# Altium schematic sheet Schemat.SchDoc
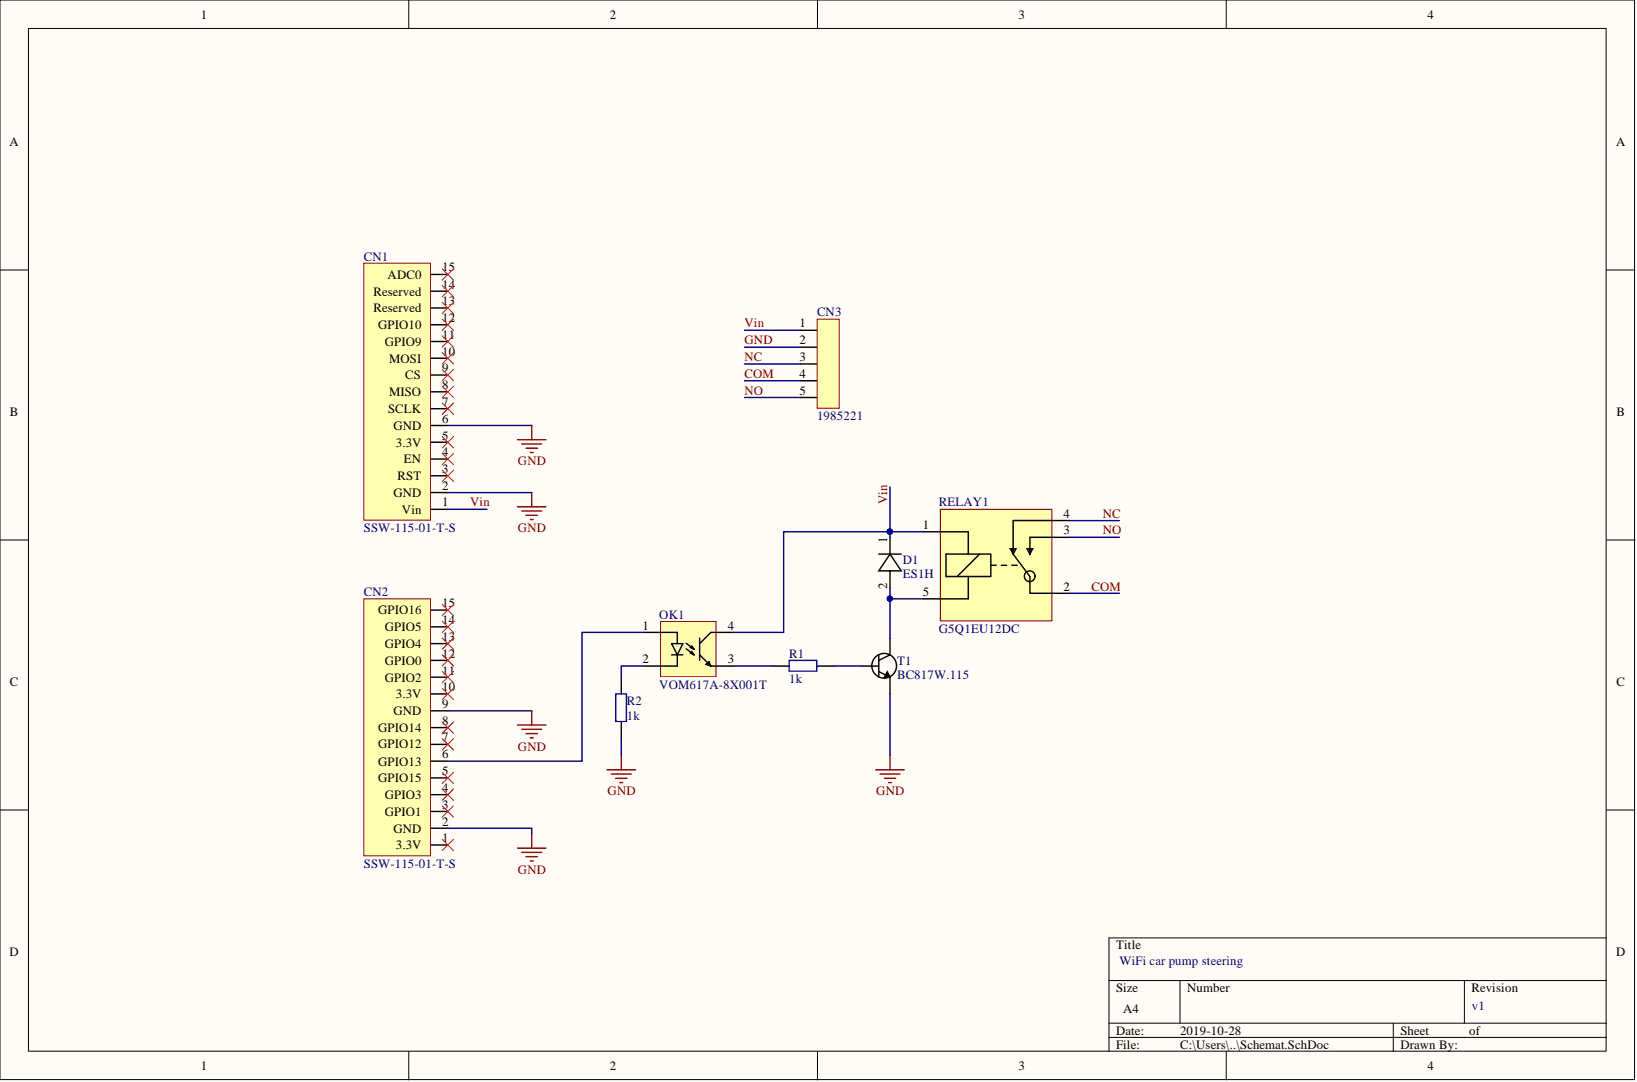
[diagram p0: Schemat.SchDoc]

## Parts
CN1  SSW-115-01-T-S  [SSW-115-01-T-S]
CN2  SSW-115-01-T-S  [SSW-115-01-T-S]
CN3  1985221  [PTSA 1.5/5-3,5-Z]
D1  ES1H  [DIOM4326X25N]
OK1  VOM617A-8X001T  [SOP254P700X200-4M]
R1  Resistance: 1k  [Resistor 1206]  (hidden comment: 1206 resistor)
R2  Resistance: 1k  [Resistor 1206]  (hidden comment: 1206 resistor)
RELAY1  G5Q1EU12DC  [G5Q-1EU 12DC]
T1  BC817W.115  [BC817W.115]

## Nets
COM: CN3.4 RELAY1.2
GND: CN1.2(GND) CN1.6(GND) CN2.2(GND) CN2.9(GND) CN3.2 R2.2 T1.2
NC: CN3.3 RELAY1.4
NO: CN3.5 RELAY1.3
Vin: CN1.1(Vin) CN3.1 D1.1 OK1.4 RELAY1.1
NetCN2_6: CN2.6(GPIO13) OK1.1
NetD1_2: D1.2 RELAY1.5 T1.3
NetOK1_2: OK1.2 R2.1
NetOK1_3: OK1.3 R1.1
NetR1_2: R1.2 T1.1

Not connected: CN1 3(RST) 4(EN) 5(3.3V) 7(SCLK) 8(MISO) 9(CS) 10(MOSI) 11(GPIO9) 12(GPIO10) 13(Reserved) 14(Reserved) 15(ADC0); CN2 1(3.3V) 3(GPIO1) 4(GPIO3) 5(GPIO15) 7(GPIO12) 8(GPIO14) 10(3.3V) 11(GPIO2) 12(GPIO0) 13(GPIO4) 14(GPIO5) 15(GPIO16)

## Library data of the parts
CN1 CN2 = SSW-115-01-T-S: SAMTEC         SSW-115-01-T-S             Board-To-Board Connector, SSW Series, 15 Contacts, Receptacle, 2.54 mm, Through Hole, 1 Rows; Connector Type: Receptacle,Socket; Contact Resistance: 10mR; Mating Cycles: 100; Max Current Rating: 6.9A; Max Voltage Rating (AC): 550V; Number of Contacts: 15; Pitch: 2.54mm; Color: Black; Component Kind: Standard; ComponentLink1Description: http://suddendocs.samtec.com/prints/ssw-1xx-xx-xxx-x-xx-xxx-xx-mkt.pdf?src-supplier=Digi-Key; ComponentLink1URL: http://suddendocs.samtec.com/prints/ssw-1xx-xx-xxx-x-xx-xxx-xx-mkt.pdf?src-supplier=Digi-Key; Contact Material: Bronze; Contact Plating: Tin,Matte; Depth: 2.41mm; Gender: Receptacle; Housing Color: Black; Housing Material: Polymer; Lead Free: Lead Free; Length: 38.61mm; Manufacturer: Samtec; Manufacturer Part Number: SSW-115-01-T-S; Max Operating Temperature: 105°C; Min Operating Temperature: -55°C; Mount: Through Hole; Number of Positions: 15; Number of Rows: 1; Orientation: Straight; Packaging: Bulk; Radiation Hardening: No; REACH SVHC: No SVHC; Stock 1: 0; Supplier 1: Farnell; Supplier Part Number 1: 1804177; Termination: Solder; Width: 2.41mm; Library Name: librariesForWifiPumpSteeringProject.SchLib
CN3 = 1985221: TERM BLOCK 5POS 45DEG 3.5MM PCB; Manufacturer: Phoenix Contact; Approvals: UL,VDE; Current: 2A; Max Supply Voltage: 250V; Number of Circuits: 5; Number of Levels: 1; Stripping Length: 8mm; Voltage: 300V; Wire/Cable Gauge: 16AWG; Wire Gauge (Max): 24AWG; Color: Green; Component Kind: Standard; ComponentLink1Description: http://components.arrow.com/part/dataview/43946157S8410404N1555?src-supplier=Arrow; ComponentLink1URL: http://components.arrow.com/part/dataview/43946157S8410404N1555?src-supplier=Arrow; ComponentLink2Description: https://media.digikey.com/pdf/Data%20Sheets/Phoenix%20Contact%20PDFs/COMBICON%20Spring-Cage%20PCB%20Term.%20Blocks.pdf?src-supplier=Digi-Key; ComponentLink2URL: https://media.digikey.com/pdf/Data%20Sheets/Phoenix%20Contact%20PDFs/COMBICON%20Spring-Cage%20PCB%20Term.%20Blocks.pdf?src-supplier=Digi-Key; Contact Material: Tin; Current Rating: 2A; Depth: 12mm; Flammability Rating: UL94 V-2; Height: 13.1mm; Housing Color: Green; Housing Material: Polyamide; Insulation Material: Nylon; Lead Free: Lead Free; Length: 12mm; Manufacturer Part Number: 1985221; Material: Nylon; Max Current Rating: 2A; Mount: Through Hole; Number of Contacts: 5; Number of Pins: 5; Number of Poles: 5; Number of Positions: 5; Number of Positions Per Level: 5; Number of Rows: 1; Package Quantity: 100; Packaging: Bulk; Pitch: 3.5mm; REACH SVHC: Unknown; Stock 1: 178; Supplier 1: Farnell; Supplier Part Number 1: 1792772; Termination: Solder; Voltage Rating: 250V; Weight: 0.087479oz; Width: 19mm; Wire Gauge (Min): 16AWG; Library Name: librariesForWifiPumpSteeringProject.SchLib
D1 = ES1H: Rectifiers 1A,500V,SUPER FAST Surf Mt Rect; Manufacturer: Taiwan Semiconductor; Element Configuration: Single; Forward Voltage: 1.7V; Peak Reverse Current: 100uA; Reverse Recovery Time: 35ns; Component Kind: Standard; ComponentLink1Description: http://uk.rs-online.com/webdocs/1298/0900766b81298786.pdf?src-supplier=RSComponents; ComponentLink1URL: http://uk.rs-online.com/webdocs/1298/0900766b81298786.pdf?src-supplier=RSComponents; ComponentLink2Description: http://www.taiwansemi.com/DSfile/ES1A%20SERIES_H13.pdf?src-supplier=Mouser; ComponentLink2URL: http://www.taiwansemi.com/DSfile/ES1A%20SERIES_H13.pdf?src-supplier=Mouser; Forward Current: 1A; Height: 2.5mm; Lead Free: Lead Free; Length: 4.6mm; Manufacturer Part Number: ES1H; Max Operating Temperature: 150°C; Max Repetitive Reverse Voltage (Vrrm): 500V; Min Operating Temperature: -55°C; Mount: Surface Mount; Number of Pins: 2; Package Quantity: 7500; Packaging: Tape and Reel; REACH SVHC: No SVHC; Stock 1: 0; Supplier 1: Farnell; Supplier Part Number 1: 2677280; Width: 2.83mm; Library Name: librariesForWifiPumpSteeringProject.SchLib
OK1 = VOM617A-8X001T: VISHAY         VOM617A-8X001T             Transistor Output Optocoupler, Low Input Current, 1 Channel, SOP, 4 Pins, 60 mA, 3.75 kV, 130 %; Collector Emitter Saturation Voltage: 400mV; Collector Emitter Voltage (VCEO): 80V; Current Transfer Ratio: 260%; Fall Time: 12us; Forward Current: 60mA; Forward Voltage: 1.6V; Isolation Voltage: 3.75kV; Max Collector Current: 100mA; Max Power Dissipation: 170mW; Number of Channels: 1; Reverse Breakdown Voltage: 6V; Rise Time: 7us; Case/Package: SOP; Component Kind: Standard; ComponentLink1Description: http://www.farnell.com/datasheets/2050092.pdf?src-supplier=Element14; ComponentLink1URL: http://www.farnell.com/datasheets/2050092.pdf?src-supplier=Element14; ComponentLink2Description: http://www.vishay.com/docs/83446/vom617a.pdf?src-supplier=Avnet+Europe; ComponentLink2URL: http://www.vishay.com/docs/83446/vom617a.pdf?src-supplier=Avnet+Europe; ComponentLink3Description: http://www.vishay.com/docs/83446/vom617a.pdf?src-supplier=Digi-Key; ComponentLink3URL: http://www.vishay.com/docs/83446/vom617a.pdf?src-supplier=Digi-Key; ComponentLink4Description: http://www.vishay.com/docs/83446/vom617a.pdf?src-supplier=Avnet; ComponentLink4URL: http://www.vishay.com/docs/83446/vom617a.pdf?src-supplier=Avnet; Input Current: 60mA; Manufacturer: Vishay; Manufacturer Part Number: VOM617A-8X001T; Max Input Current: 60mA; Max Operating Temperature: 110°C; Min Operating Temperature: -55°C; Mount: Surface Mount; Number of Elements: 1; Number of Pins: 4; Output Current per Channel: 100mA; Output Voltage: 80V; Package Quantity: 3000; Packaging: Tape and Reel; Power Dissipation: 170mW; Radiation Hardening: No; REACH SVHC: Unknown; Stock 1: 859; Supplier 1: Farnell; Supplier Part Number 1: 2251591; Library Name: librariesForWifiPumpSteeringProject.SchLib
R1 R2 = CRCW1206220RJNTABC: Resistor: thick film; SMD; 1206; 220O; 0.25W; ±5%; -55÷125°C; Manufacturer: Vishay; Power Rating: 250mW; Case/Package: 1206; Component Kind: Standard; Lead Free: Contains Lead; Manufacturer Part Number: CRCW1206220RJNTABC; Pricing 1: 100=0.0314, 500=0.01833, 1000=0.01178, 5000=0.005, 15000=0.00487 (USD); Stock 1: 277400; Supplier 1: TME; Supplier Part Number 1: CRCW1206220RJNTABC; Tolerance: 5%; Library Name: counter_of_area_parts.SchLib
RELAY1 = G5Q1EU12DC: PCB power relay 12 VDC 400 mW, G5Q1EU12DC, Omron Electronic Components; Coil Current: 33.3mA; Coil Power: 400mW; Coil Resistance: 360R; Coil Type: Non-Latching; Coil Voltage (DC): 12V; Contact Current Rating: 10A; Contact Resistance: 100mR; Operate Time: 10ms; Ratings: IP67; Switching Capacity: 1.25kW; Throw Configuration: SPDT; Voltage Rating (AC): 277V; Voltage Rating (DC): 30V; Component Kind: Standard; ComponentLink1Description: http://www.produktinfo.conrad.com/datenblaetter/500000-524999/503931-da-01-en-KOMPAKT_PCB_POWERRELAIS_G5Q_1_EU_12DC.pdf?src-supplier=Conrad; ComponentLink1URL: http://www.produktinfo.conrad.com/datenblaetter/500000-524999/503931-da-01-en-KOMPAKT_PCB_POWERRELAIS_G5Q_1_EU_12DC.pdf?src-supplier=Conrad; Contact Material: Silver; Current Rating: 33.3mA; Depth: 10.3mm; Height: 15.8mm; Insulation Resistance: 100MR; Length: 20.3mm; Manufacturer: Omron; Manufacturer Part Number: G5Q1EU12DC; Max Operating Temperature: 85°C; Min Operating Temperature: -40°C; Mount: PCB; Number of Pins: 5; Radiation Hardening: No; REACH SVHC: Unknown; Stock 1: 634; Supplier 1: Farnell; Supplier Part Number 1: 4446434; Switching Current: 10A; Termination: PC Pin; Width: 10.3mm; Library Name: librariesForWifiPumpSteeringProject.SchLib
T1 = BC817W,115: TRANS NPN 45V 0.5A SOT323; Manufacturer: Nexperia USA; Component Kind: Standard; Library Name: mosfet_STM32.SchLib; Manufacturer Part Number: BC817W,115; Stock 1: 0; Supplier 1: Farnell; Supplier Part Number 1: 2439014
